# Altium schematic sheet SRAM_QPI.SchDoc
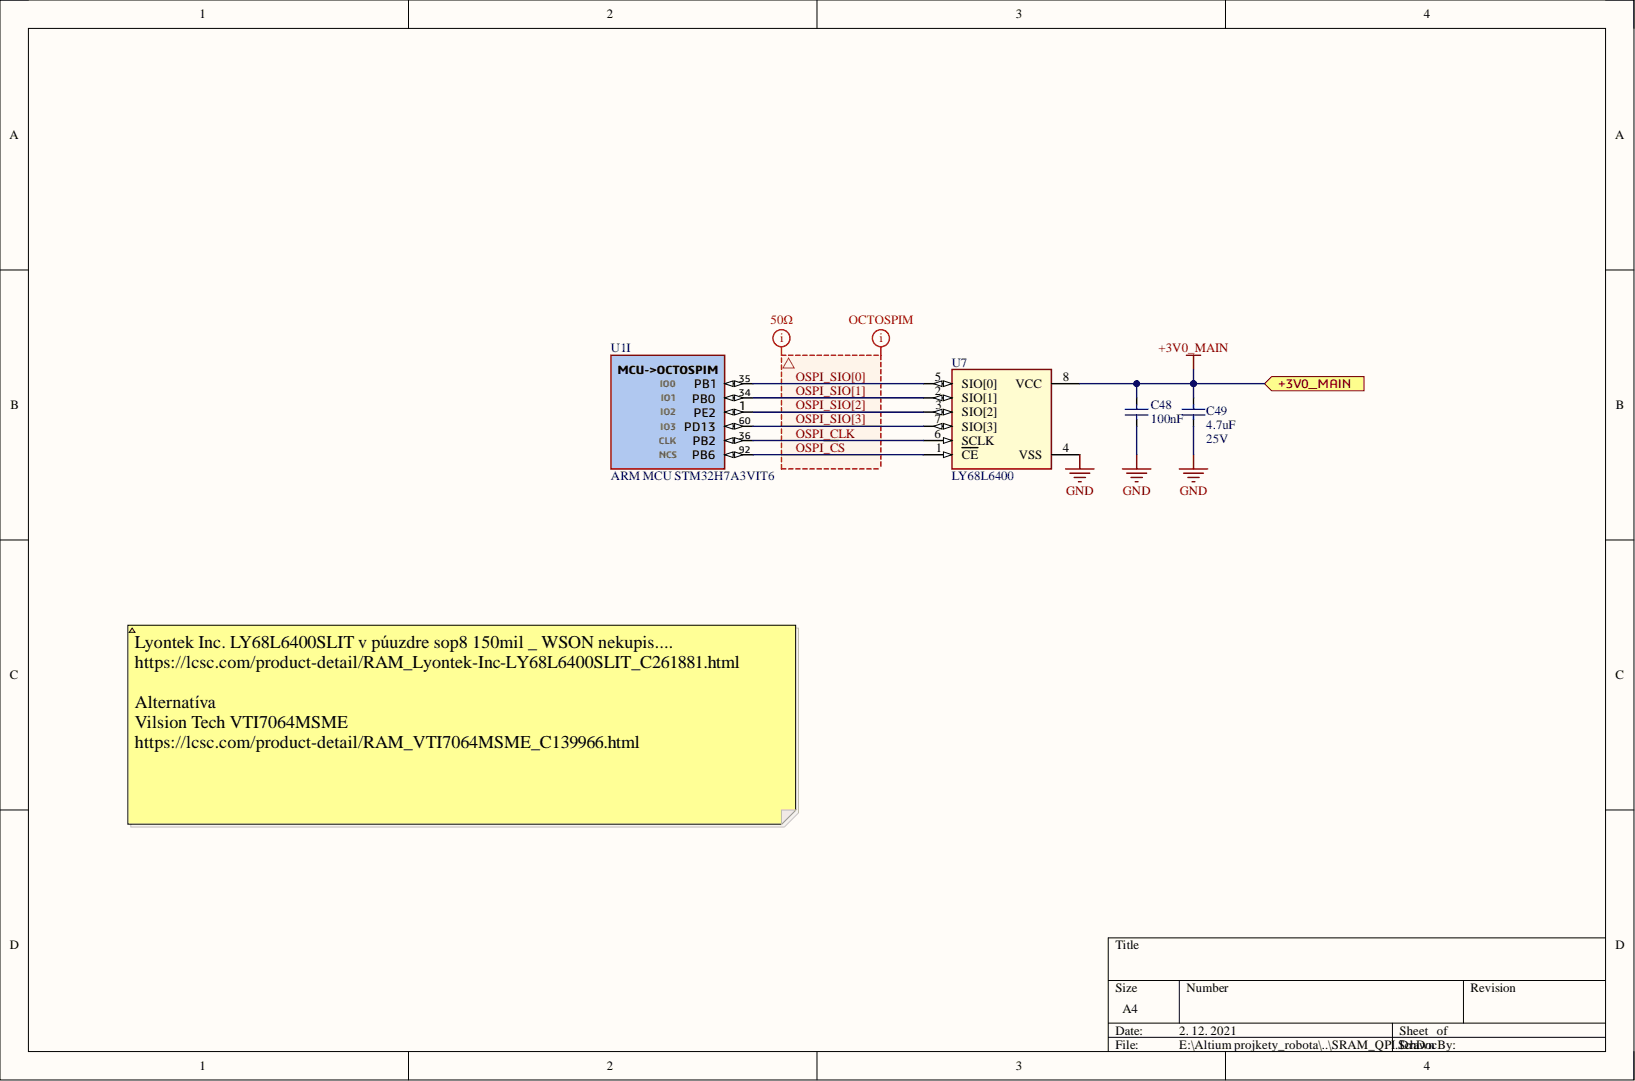
[diagram p0: SRAM_QPI.SchDoc]

## Parts
C48  100nF  [CAPACITOR_0402_(1005)]
C49  4.7uF  Voltage: 25V  [CAPACITOR_0603_(1608)]
U1  ARM MCU STM32H7A3VIT6  Manufacturer Part Number: STM32H7A3VIT6  [STM-LQFP100_N - Edited]  (part I)
U7  LY68L6400  [SOIC8 (SOP)]

## Ports
+3V0_MAIN

## Nets
GND: C48.1 C49.2 U7.4(VSS)
+3V0_MAIN: C48.2 C49.1 U7.8(VCC) ; port +3V0_MAIN
OSPI~{_C}S: U1.92(PB6) U7.1(CE)
OSPI_CLK: U1.36(PB2) U7.6(SCLK)
OSPI_SIO[0]: U1.35(PB1) U7.5(SIO[0])
OSPI_SIO[1]: U1.34(PB0) U7.2(SIO[1])
OSPI_SIO[2]: U1.1(PE2) U7.3(SIO[2])
OSPI_SIO[3]: U1.60(PD13) U7.7(SIO[3])

## Library data of the parts
C48 = CAPACITOR: ESR: 0.28R; Voltage Rating: 10V; Capacitance: 100nF; Component Kind: Standard; Description: CAPACITOR; Library Name: RLCFB.SchLib
C49 = CAPACITOR: Manufacturer: Murata; Manufacturer Part Number 1: GRM188R61E475KE11D; Dielectric: X5R; ESR: 0.28R; Material: Ceramic; Tolerance: 10%; Capacitance: 4.7uF; Case Code (Imperial): 0603; Case Code (Metric): 1608; Case/Package: 0603; Component Kind: Standard; ComponentLink1Description: https://datasheet.ciiva.com/46444/1769893-46444982.pdf?src-supplier=Element14; ComponentLink1URL: https://datasheet.ciiva.com/46444/1769893-46444982.pdf?src-supplier=Element14; ComponentLink2Description: https://datasheet.ciiva.com/46444/1769893-46444983.pdf?src-supplier=Element14; ComponentLink2URL: https://datasheet.ciiva.com/46444/1769893-46444983.pdf?src-supplier=Element14; ComponentLink3Description: https://datasheet.ciiva.com/14891/partnumbering-e-01-14891102.pdf?src-supplier=Digi-Key; ComponentLink3URL: https://datasheet.ciiva.com/14891/partnumbering-e-01-14891102.pdf?src-supplier=Digi-Key; ComponentLink4Description: https://datasheet.ciiva.com/14891/partnumbering-e-01-14891103.pdf?src-supplier=Digi-Key; ComponentLink4URL: https://datasheet.ciiva.com/14891/partnumbering-e-01-14891103.pdf?src-supplier=Digi-Key; Depth: 0.8mm; Description: CAPACITOR; Height: 0.8mm; Lead Free: Lead Free; Length: 1.6mm; Max Operating Temperature: 85°C; Min Operating Temperature: -55°C; Mount: Surface Mount; Package Quantity: 4000; Packaging: Cut Tape; Pricing 1: 10=0,35700, 100=100,00000, 500=0,15900, 1000=0,12800, 2000=0,10200 (CHF); REACH SVHC: No SVHC; RoHS: Yes; Stock 1: 95119; Supplier 1: Farnell; Supplier Part Number 1: 2426959; Thickness: 0.031inch; Voltage Rating: 25V; Voltage Rating (DC): 25V; Width: 0.031inch; Library Name: RLCFB.SchLib
U1 = STM32H7A3VIT6_LQFP100: STM32H7A3VIT6 -  32-bit Arm® Cortex®-M7 280 MHz MCUs, up to 2-Mbyte Flash memory, 1.4Mbyte RAM, 46 com. and analog interfaces; Manufacturer: STMicroelectronics; Category: Microcontrollers; Component Kind: Standard; ComponentLink1Description: Datasheet; ComponentLink1URL: https://www.st.com/resource/en/datasheet/stm32h7a3vi.pdf; Library Name: BB1_SCH_Library.SchLib; RoHS: No; Supplier 1: Mouser; Supplier Part Number 1: 511-STM32H7A3VIT6
U7 = SRAM-Pseudo_64M Bits_QPI: 64M Bits Serial Pseudo-SRAM with SPI and QPI; Manufacturer: Lyontek Inc.; Mfr.Part: LY68L6400SLIT; Replace: VTI7064MSME; Buy Address: https://lcsc.com/product-detail/RAM_Lyontek-Inc-LY68L6400SLIT_C261881.html; Component Kind: Standard; ComponentLink1Description: Datasheet; ComponentLink1URL: https://datasheet.lcsc.com/szlcsc/1809140531_Lyontek-Inc-LY68L6400SLIT_C261881.pdf; ComponentLink2Description: Buy Address; ComponentLink2URL: https://lcsc.com/product-detail/RAM_Lyontek-Inc-LY68L6400SLIT_C261881.html; LCSC Part: C261881; Library Name: BB1_SCH_Library.SchLib
